# Altium schematic sheet Sheet1.SchDoc
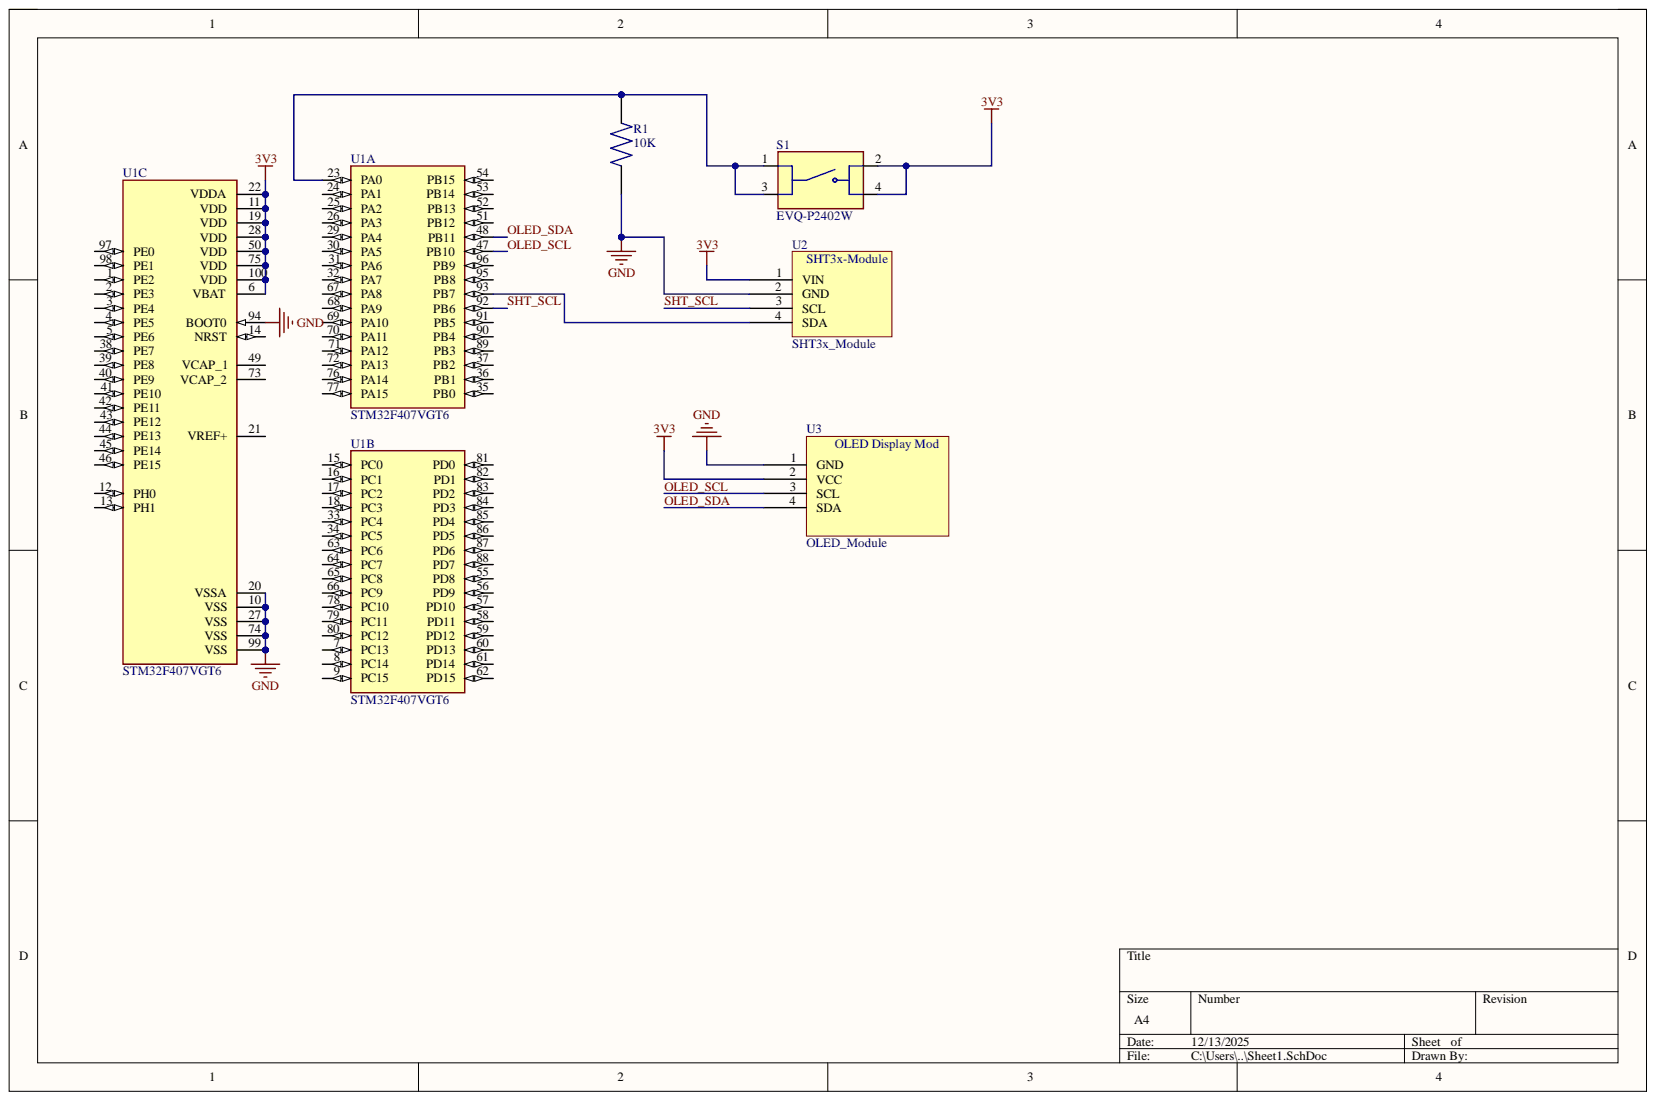
[diagram p0: Sheet1.SchDoc]

## Parts
R1  10K  [RESC3115X65N]
S1  EVQ-P2402W  [SW_EVQ-P2402W]
U1  STM32F407VGT6  [QFP50P1600X1600X160-100N]  (parts A,B,C)
U2  SHT3x_Module
U3  OLED_Module

## Nets
3V3: S1.2 S1.4 U1.6(VBAT) U1.11(VDD) U1.19(VDD) U1.22(VDDA) U1.28(VDD) U1.50(VDD) U1.75(VDD) U1.100(VDD) U2.1(VIN) U3.2(VCC)
GND: R1.1 U1.10(VSS) U1.20(VSSA) U1.27(VSS) U1.74(VSS) U1.94(BOOT0) U1.99(VSS) U2.2(GND) U3.1(GND)
OLED_SCL: U1.47(PB10) U3.3(SCL)
OLED_SDA: U1.48(PB11) U3.4(SDA)
SHT_SCL: U1.92(PB6) U2.3(SCL)
NetR1_2: R1.2 S1.1 S1.3 U1.23(PA0)
NetU1_93: U1.93(PB7) U2.4(SDA)

Not connected: U1 1(PE2) 2(PE3) 3(PE4) 4(PE5) 5(PE6) 7(PC13) 8(PC14) 9(PC15) 12(PH0) 13(PH1) 14(NRST) 15(PC0) 16(PC1) 17(PC2) 18(PC3) 21(VREF+) 24(PA1) 25(PA2) 26(PA3) 29(PA4) 30(PA5) 31(PA6) 32(PA7) 33(PC4) 34(PC5) 35(PB0) 36(PB1) 37(PB2) 38(PE7) 39(PE8) 40(PE9) 41(PE10) 42(PE11) 43(PE12) 44(PE13) 45(PE14) 46(PE15) 49(VCAP_1) 51(PB12) 52(PB13) 53(PB14) 54(PB15) 55(PD8) 56(PD9) 57(PD10) 58(PD11) 59(PD12) 60(PD13) 61(PD14) 62(PD15) 63(PC6) 64(PC7) 65(PC8) 66(PC9) 67(PA8) 68(PA9) 69(PA10) 70(PA11) 71(PA12) 72(PA13) 73(VCAP_2) 76(PA14) 77(PA15) 78(PC10) 79(PC11) 80(PC12) 81(PD0) 82(PD1) 83(PD2) 84(PD3) 85(PD4) 86(PD5) 87(PD6) 88(PD7) 89(PB3) 90(PB4) 91(PB5) 95(PB8) 96(PB9) 97(PE0) 98(PE1)

## Library data of the parts
R1 = CRGP1206F220K: Fixed Resistor CRGP 1206 220K 1%; MF: TE Connectivity; Check_prices: https://www.snapeda.com/parts/CRGP1206F220K/TE+Connectivity/view-part/?ref=eda; Component Kind: Standard; Library Name: Schlib1.SchLib; MP: CRGP1206F220K; Package: 3115 TE Connectivity; SnapEDA_Link: https://www.snapeda.com/parts/CRGP1206F220K/TE+Connectivity/view-part/?ref=snap
S1 = EVQ-P2402W: MF: {'manufacturer': u'Panasonic Electronic Components'}; Availability: Unavailable; Component Kind: Standard; Library Name: Schlib1.SchLib; MP: EVQ-P2402W; Package: None; Price: None
U1 = STM32F407VGT6: ARMÂ® CortexÂ®-M4 STM32F4 Microcontroller IC 32-Bit Single-Core 168MHz 1MB (1M x 8) FLASH 100-LQFP (14x14); MF: STMicroelectronics; Check_prices: https://www.snapeda.com/parts/STM32F407VGT6/STMicroelectronics/view-part/?ref=eda; Component Kind: Standard; Library Name: Schlib1.SchLib; MP: STM32F407VGT6; Package: LQFP-100 STMicroelectronics; SnapEDA_Link: https://www.snapeda.com/parts/STM32F407VGT6/STMicroelectronics/view-part/?ref=snap
U2 = SHT3x_Module: Component Kind: Standard; Library Name: Schlib1.SchLib
U3 = OLED_Module: Component Kind: Standard; Library Name: Schlib1.SchLib
